# Altium schematic sheet POWER.SchDoc(POWER)
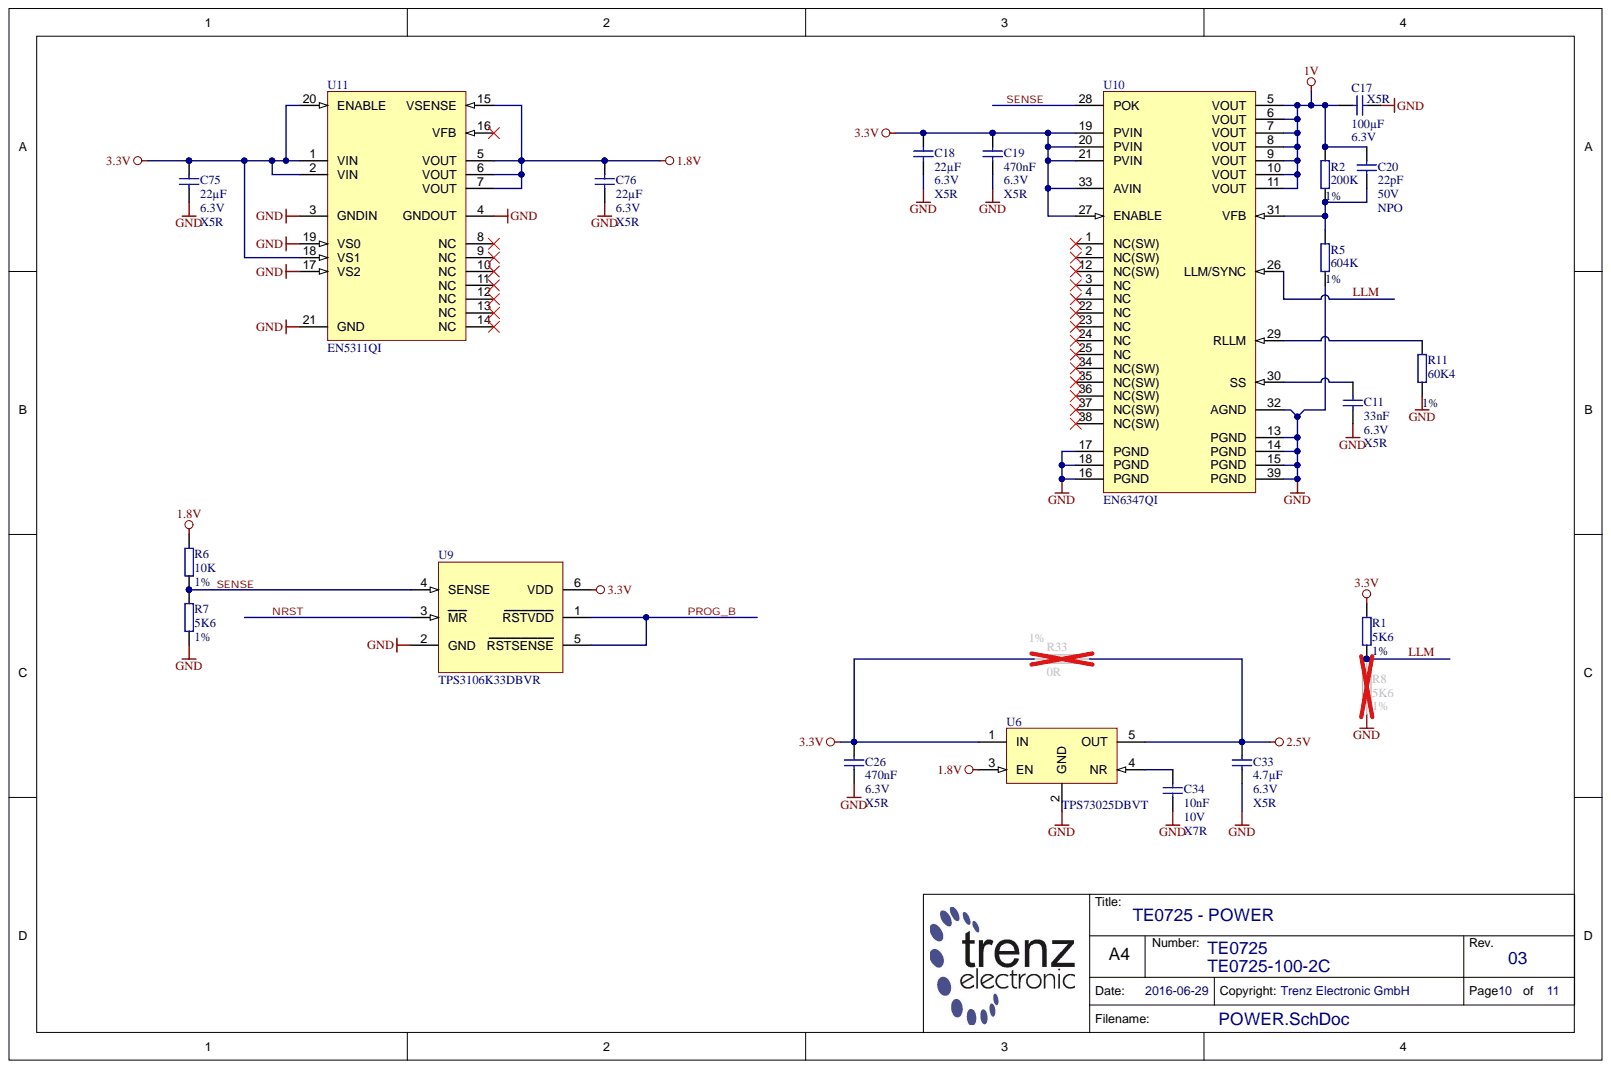
[diagram p0: POWER.SchDoc]

## Parts
C11  33nF  Technology: X5R  Voltage: 6.3V  [CAPC0603X33N]
C17  100µF  Technology: X5R  [CAPC3216X180N]
C18  22µF  Technology: X5R  Voltage: 6.3V  [CAPC2012X135N]
C19  470nF  Technology: X5R  Voltage: 6.3V  [CAPC0603X33N]
C20  22pF  Technology: NPO  Voltage: 50V  [CAPC1005X55N]
C26  470nF  Technology: X5R  Voltage: 6.3V  [CAPC0603X33N]
C33  4.7µF  Technology: X5R  Voltage: 6.3V  [CAPC1005X60N]
C34  10nF  Technology: X7R  Voltage: 10V  [CAPC0603X33N]
C75  22µF  Technology: X5R  Voltage: 6.3V  [CAPC2012X135N]
C76  22µF  Technology: X5R  Voltage: 6.3V  [CAPC2012X135N]
R1  5K6  Tolerance: 1%  [RESC1005X40N]
R2  200K  Tolerance: 1%  [RESC1005X40N]
R5  604K  Tolerance: 1%  [RESC1005X40N]
R6  10K  Tolerance: 1%  [RESC1005X40N]
R7  5K6  Tolerance: 1%  [RESC1005X40N]
R8  5K6  Tolerance: 1%  [RESC1005X40N]
R11  60K4  Tolerance: 1%  [RESC1005X40N]
R33  0R  Tolerance: 1%  [RESC1608X55N]
U6  TPS73025DBVT  [SOT95P280X145-5N]
U9  TPS3106K33DBVR  [SOT95P280X145-6N]
U10  EN6347QI  [EN63xx]
U11  EN5311QI  [EN5311QI]

## Nets
1.8V: C76.1 R6.1 U6.3(EN) U11.5(VOUT) U11.6(VOUT) U11.7(VOUT) U11.15(VSENSE)
1V: C17.1 C20.1 R2.1 U10.5(VOUT) U10.6(VOUT) U10.7(VOUT) U10.8(VOUT) U10.9(VOUT) U10.10(VOUT) U10.11(VOUT)
2.5V: C33.2 R33.2 U6.5(OUT)
3.3V: C18.1 C19.2 C26.1 C75.1 R1.2 R33.1 U6.1(IN) U9.6(VDD) U10.19(PVIN) U10.20(PVIN) U10.21(PVIN) U10.27(ENABLE) U10.33(AVIN) U11.1(VIN) U11.2(VIN) U11.18(VS1) U11.20(ENABLE)
GND: C11.2 C17.2 C18.2 C19.1 C26.2 C33.1 C34.2 C75.2 C76.2 R5.2 R7.2 R8.1 R11.1 U6.2(GND) U9.2(GND) U10.13(PGND) U10.14(PGND) U10.15(PGND) U10.16(PGND) U10.17(PGND) U10.18(PGND) U10.32(AGND) U10.39(PGND) U11.3(GNDIN) U11.4(GNDOUT) U11.17(VS2) U11.19(VS0) U11.21(GND)
LLM: R1.1 R8.2 U10.26(LLM/SYNC)
NRST: U9.3(MR)
PROG_B: U9.1(RSTVDD) U9.5(RSTSENSE)
SENSE: R6.2 R7.1 U9.4(SENSE) U10.28(POK)
NetC11_1: C11.1 U10.30(SS)
NetC20_2: C20.2 R2.2 R5.1 U10.31(VFB)
NetC34_1: C34.1 U6.4(NR)
NetR11_2: R11.2 U10.29(RLLM)

Not connected: U10 1(NC(SW)) 2(NC(SW)) 3(NC) 4(NC) 12(NC(SW)) 22(NC) 23(NC) 24(NC) 25(NC) 34(NC(SW)) 35(NC(SW)) 36(NC(SW)) 37(NC(SW)) 38(NC(SW)); U11 8(NC) 9(NC) 10(NC) 11(NC) 12(NC) 13(NC) 14(NC) 16(VFB)

## Library data of the parts
C11 = 24923: KON 33nF X5R 6.3V SMD 10%; Database Table Name: C_0603_0201; Mixed: SMT; Preferred: Yes; Value: 33n; AN: 24923; Case: 0603 (0201); Component Kind: Standard; Library Name: TE.DbLib; Tolerance: 10%
C17 = 23116: KON 100µF 6,3V X5R SMD 20%; Database Table Name: C_3216_1206; Mixed: SMT; Preferred: Yes; Value: 100u; Voltage: 6.3V; AN: 23116; Case: 3216 (1206); Component Kind: Standard; Library Name: TE.DbLib; Tolerance: 20%
C18 C75 C76 = 23410: KON 22µF 6,3V  X5R SMD; Database Table Name: C_2012_0805; Mixed: SMT; Value: 22u; AN: 23410; Case: 2012 (0805); Component Kind: Standard; Library Name: TE.DbLib
C19 C26 = 24921: KON 470nF X5R 6.3V SMD 20%; Database Table Name: C_0603_0201; Mixed: SMT; Preferred: Yes; Value: 470n; AN: 24921; Case: 0603 (0201); Component Kind: Standard; Library Name: TE.DbLib; Tolerance: 20%
C20 = 22581: KON 22pF NPO 50V SMD 5%; Database Table Name: C_1005_0402; Mixed: SMT; Preferred: Yes; Value: 22p; AN: 22581; Case: 1005 (0402); Component Kind: Standard; Library Name: TE.DbLib; Tolerance: 5%
C33 = 24719: KON 4.7µF 6.3V X5R SMD 20%; Database Table Name: C_1005_0402; Mixed: SMT; Preferred: Yes; Value: 4.7u; AN: 24719; Case: 1005 (0402); Component Kind: Standard; Library Name: TE.DbLib; Tolerance: 20%
C34 = 26312: KON 10nF X7R 10V SMD 10%; Database Table Name: C_0603_0201; Mixed: SMT; Preferred: Yes; Value: 10n; AN: 26312; Case: 0603 (0201); Component Kind: Standard; Library Name: TE.DbLib; Tolerance: 10%
R1 R7 R8 = 22760: WID SMD 5K6 1% 0,063W; Database Table Name: R_1005_0402; Mixed: SMT; Value: 5.6K; AN: 22760; Case: 1005 (0402); Component Kind: Standard; Library Name: TE.DbLib; Technology: TK100
R2 = 23846: WID SMD 200K 1% 0,063W; Database Table Name: R_1005_0402; Mixed: SMT; Preferred: Yes; AN: 23846; Case: 1005 (0402); Component Kind: Standard; Library Name: TE.DbLib; Technology: TK100; Value: 200K
R5 = 24712: WID SMD 604K 1% 0,063W; Database Table Name: R_1005_0402; Mixed: SMT; Preferred: Yes; AN: 24712; Case: 1005 (0402); Component Kind: Standard; Library Name: TE.DbLib; Technology: TK100; Value: 604k
R6 = 22750: WID SMD 10K 1% 0,063W; Database Table Name: R_1005_0402; Mixed: SMT; Preferred: Yes; AN: 22750; Case: 1005 (0402); Component Kind: Standard; Library Name: TE.DbLib; Technology: TK100; Value: 10K
R11 = 23156: WID SMD 60K4 1% 0,063W; Database Table Name: R_1005_0402; Mixed: SMT; Value: 60.4K; AN: 23156; Case: 1005 (0402); Component Kind: Standard; Library Name: TE.DbLib; Technology: TK100
R33 = 21491: WID SMD 0R 0,1W 1% TK100; Database Table Name: R_1608_0603; Mixed: SMT; Value: 0; AN: 21491; Case: 1608 (0603); Component Kind: Standard; Library Name: TE.DbLib; Technology: TK100
U6 = 22676: Database Table Name: LREG; Mixed: SMT; Trenz-Stock: Yes; AN: 22676; Case: SOT23-5; Component Kind: Standard; Description: TPS73025DBVT; H-ART: TPS73025DBVT; Library Name: TE.DbLib; HelpURL: B:\AD\adlib\datasheet\TPS730XX
U9 = 26439: Database Table Name: SUPERVISOR; Mixed: SMT; AN: 26439; Case: SOT23-6; Component Kind: Standard; Description: TPS3106K33DBVR; H-ART: TPS3106K33DBVR; Library Name: TE.DbLib; HelpURL: B:\ad\adlib\datasheet\TPS3106
U10 = 24486: Database Table Name: DCDC; Mixed: SMT; Preferred: Yes; AN: 24486; Component Kind: Standard; Description: EN6347QI; H-ART: EN6347QI; Library Name: TE.DbLib; Trenz-Stock: Yes; HelpURL: B:\AD\adlib\datasheet\EN6347QI
U11 = 25492: Database Table Name: DCDC; Mixed: SMT; Preferred: Yes; AN: 25492; Case: QFN20; Component Kind: Standard; Description: EN5311QI; H-ART: EN5311QI; Library Name: TE.DbLib; Trenz-Stock: Yes; HelpURL: B:\AD\adlib\datasheet\EN5311QI
